# Altium schematic sheet Mainboard.SchDoc
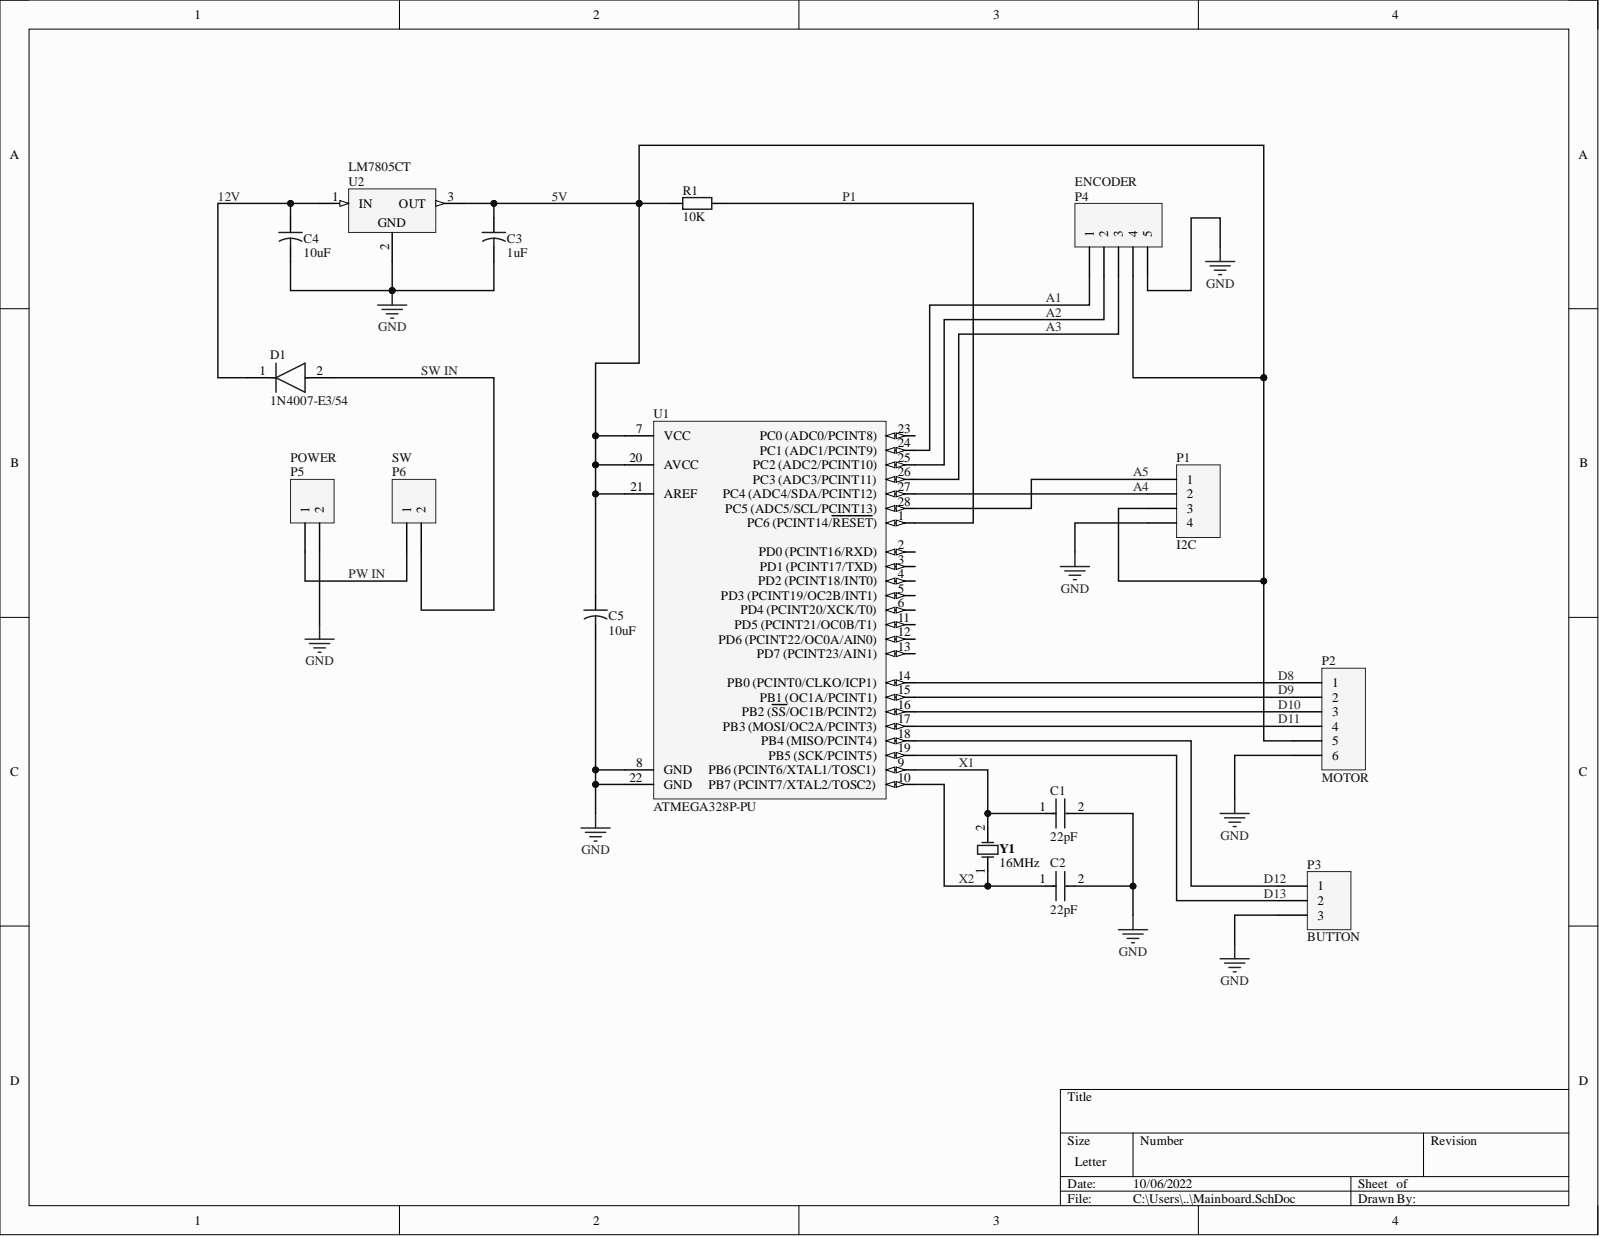
[diagram p0: Mainboard.SchDoc]

## Parts
C1  22pF  [FP-RCE0DB-MFG]
C2  22pF  [FP-RCE0DB-MFG]
C3  1uF  [CAPR5-4X5]
C4  10uF  [CAPR5-4X5]
C5  10uF  [CAPR5-4X5]
D1  1N4007-E3/54  [FP-1N4007-MFG]
P1  I2C  [HDR1X4]
P2  MOTOR  [HDR1X6]
P3  BUTTON  [HDR1X3]
P4  ENCODER  [HDR1X5]
P5  POWER  [HDR1X2]
P6  SW  [HDR1X2]
R1  10K  [AXIAL-0.4]
U1  ATMEGA328P-PU  [ATML-28P3]
U2  LM7805CT  [FAIR-TO-220-3]
Y1  16MHz  [FOX-FOXSLF160-20]

## Nets
GND: C1.2 C2.2 C3.2 C4.2 C5.2 P1.4 P2.6 P3.3 P4.5 P5.2 U1.8(GND) U1.22(GND) U2.2
5V: C3.1 C5.1 P1.3 P2.5 P4.4 R1.1 U1.7(VCC) U1.20(AVCC) U1.21(AREF) U2.3
12V: C4.1 D1.1 U2.1
A1: P4.1 U1.24(PC1_(ADC1/PCINT9))
A2: P4.2 U1.25
A3: P4.3 U1.26(PC3_(ADC3/PCINT11))
A4: P1.2 U1.27
A5: P1.1 U1.28(PC5_(ADC5/SCL/PCINT13))
D8: P2.1 U1.14(PB0_(PCINT0/CLKO/ICP1))
D9: P2.2 U1.15(PB1_(OC1A/PCINT1))
D10: P2.3 U1.16(PB2_(SS/OC1B/PCINT2))
D11: P2.4 U1.17(PB3_(MOSI/OC2A/PCINT3))
D12: P3.1 U1.18(PB4_(MISO/PCINT4))
D13: P3.2 U1.19(PB5_(SCK/PCINT5))
P1: R1.2 U1.1(PC6_(PCINT14/RESET))
PW IN: P5.1 P6.1
SW IN: D1.2 P6.2
X1: C1.1 U1.9 Y1.2
X2: C2.1 U1.10 Y1.1

Not connected: U1 2(PD0_(PCINT16/RXD)) 3(PD1_(PCINT17/TXD)) 4(PD2_(PCINT18/INT0)) 5(PD3_(PCINT19/OC2B/INT1)) 6(PD4_(PCINT20/XCK/T0)) 11(PD5_(PCINT21/OC0B/T1)) 12(PD6_(PCINT22/OC0A/AIN0)) 13(PD7_(PCINT23/AIN1)) 23(PC0_(ADC0/PCINT8))

## Library data of the parts
C1 C2 = CMP-06035-057691-1: Cap Ceramic 22pF 50V C0G ±5% Radial 2.5mm +125°C Ammo Pack; Lead Diameter: 0.5mm; Lead/Base Style: Straight; Capacitance: 22pF; Case/Package: Radial; Component Kind: Standard; Dielectric: C0G; Height: 3.5mm; Height - Seated (Max): 0.138inch; Lead Pitch: 0.098inch; Length: 0.142inch; Library Name: Altium Content Vault; Max Operating Temperature: 125°C; Min Operating Temperature: -55°C; Mount: Through Hole; Package Quantity: 2000; Packaging: Tape and Reel; Ratings: AEC-Q200; Resistor Type: Ceramic; Termination: Radial; Tolerance: 5%; Voltage Rating: 50V; Voltage Rating (DC): 50V; Width: 0.098inch
C3-C5 = Cap2: Capacitor; Component Kind: Standard; Library Name: Miscellaneous Devices.IntLib; PackageDescription: Capacitor; 2 Leads; PackageReference: CAPR5-4X5; Published: 23-Sep-2002; Signal Integrity: Cap; Simulation: CAP; Publisher: Altium Limited
  C3: Value: 1uF
  C4 C5: Value: 10uF
D1 = CMP-02540-000046-1: DIODE GEN PURP 1KV 1A DO204AL; Manufacturer: Vishay; Capacitance: 15pF; Max Junction Temp: +150°C; Max Repetitive Reverse Voltage: 1000V; Max Surge Forward Current: 30A; Peak Reverse Current: 30uA; Value: 15pF; Automotive: No; Category: Diode; Component Kind: Standard; ComponentLink2Description: Datasheet; ComponentLink2URL: http://www.vishay.com/docs/88503/1n4001.pdf; Device Class L1: Discrete Semiconductors; Device Class L2: Diodes; Device Class L3: Rectifier Diodes; Digikey Description: DIODE GEN PURP 1KV 1A DO204AL; Forward Current: 1A; Height: 2.7mm; Ipc Land Pattern Name: DIOPA1088W105L520D270; Lead Free: Yes; Library Name: Altium Content Vault; Manufacturer Part Number: 1N4007-E3/54; Mouser Description: Rectifiers Vr/1000V Io/1A; Octopart: https://octopart.com/1n4007-e3%2F54-vishay-39548207; Package: 1N4007; RoHS: TRUE; Temperature: -50°C to +150°C; Temperature Range High: +150°C; Temperature Range Low: -50°C
P1 = Header 4: Header, 4-Pin; Component Kind: Standard; LatestRevisionDate: 17-Jul-2002; LatestRevisionNote: Re-released for DXP Platform.; Library Name: Miscellaneous Connectors.IntLib; Signal Integrity: Connector; Publisher: Altium Limited
P2 = Header 6: Header, 6-Pin; Component Kind: Standard; LatestRevisionDate: 17-Jul-2002; LatestRevisionNote: Re-released for DXP Platform.; Library Name: Miscellaneous Connectors.IntLib; Signal Integrity: Connector; Publisher: Altium Limited
P3 = Header 3: Header, 3-Pin; Component Kind: Standard; LatestRevisionDate: 17-Jul-2002; LatestRevisionNote: Re-released for DXP Platform.; Library Name: Miscellaneous Connectors.IntLib; Signal Integrity: Connector; Publisher: Altium Limited
P4 = Header 5: Header, 5-Pin; Component Kind: Standard; LatestRevisionDate: 17-Jul-2002; LatestRevisionNote: Re-released for DXP Platform.; Library Name: Miscellaneous Connectors.IntLib; Signal Integrity: Connector; Publisher: Altium Limited
P5 P6 = Header 2: Header, 2-Pin; Component Kind: Standard; LatestRevisionDate: 17-Jul-2002; LatestRevisionNote: Re-released for DXP Platform.; Library Name: Miscellaneous Connectors.IntLib; Signal Integrity: Connector; Publisher: Altium Limited
R1 = Res2: Resistor; Component Kind: Standard; LatestRevisionDate: 17-Jul-2002; LatestRevisionNote: Re-released for DXP Platform.; Library Name: Miscellaneous Devices.IntLib; PackageDescription: Axial Device, Thru-Hole; 2 Leads; 0.4 in Pin Spacing; PackageReference: AXIAL-0.4; Published: 8-Jun-2000; Signal Integrity: Res; Simulation: RESISTOR; Value: 10K; Publisher: Altium Limited
U1 = CMP-020-00004-3: 8-Bit Microcontroller with 32 KBytes In-System Programmable Flash, 23 I/Os, -40 to 85 degC, 28-Pin PDIP (28P3), RoHS, Tube; Manufacturer: Atmel; Density: 32KB; Ethernet Channels: 0; I/Os: 23; Interface: USART; Max Clock Frequency: 20MHz; Max Supply Voltage: 5.5V; Min Supply Voltage: 1.8V; Package Version: Rev. B, 09/2001; RAM Size: 2KB; Case/Package: DIP; Component Kind: Standard; Datasheet URL: http://www.atmel.com/images/Atmel-8271-8-bit-AVR-Microcontroller-ATmega48A-48PA-88A-88PA-168A-168PA-328-328P_datasheet_Complete.pdf; Datasheet Version: Rev. 8271J, 11/2015; Manufacturer URL: http://www.atmel.com/; Max Operating Temperature: 85°C; Min Operating Temperature: -40°C; Mounting Technology: TH; Package Description: 28-Pin Plastic Dual Inline Package, Body 34.671 x 7.3025 mm, Pitch 2.54 mm; Package Quantity: 14; Package Reference: 28P3; Package URL: http://www.atmel.com/images/Atmel-8271-8-bit-AVR-Microcontroller-ATmega48A-48PA-88A-88PA-168A-168PA-328-328P_datasheet_Complete.pdf; Packaging: Rail/Tube; Pins: 28; RoHS Compliant: True; Library Name: University of Moratuwa
U2 = CMP-2000-04938-1: Positive Voltage Regulator, 5 V, 1 A, -40 to 125 degC, 3-Pin TO-220, RoHS, Tube; Manufacturer: FairchildSemiconductor; Accuracy: ±4%; Line Regulation: 100mV; Maximum Input Voltage: 35V; Maximum Output Current: 2.2(Typ)A; Maximum Quiescent Current: 8mA; Number of Outputs: 1; Output Type: Fixed; Output Voltage: 5V; Rad Hard: No; Typical Dropout Voltage @ Current: 2@1AV; Category: LinearRegulator; Component Kind: Standard; Datasheet URL: https://datasheet.ciiva.com/26904/lm7805ct-26904988.pdf; Datasheet Version: Aug-12; Library Name: Altium Content Vault; Load Regulation: 100mV; Manufacturer URL: https://www.fairchildsemi.com/; Mounting: ThroughHole; Operating Temperature: -40to125degC; Package: 3TO-220AB; Package Description: 3-Pin Through Hole Device, Body 10 x 4.5 mm, Pitch 2.54 mm; Package Reference: TO-220; Package URL: https://www.fairchildsemi.com/package-drawings/TO/TO220B03.pdf; Packaging: Rail/Tube; Polarity: Positive; Type: Linear
Y1 = CMP-1749-00003-1: Resistance Weld Thru-Hole Crystal, 16 MHz, -20 to 70 degC, 2-Pin THD, RoHS, Bulk; Case\Package: Axial; Power Rating: 250 mW; Resistance: 221 kohm; Tolerance: 1%; Voltage Rating: 250 V; Component Kind: Standard; ComponentLink1Description: Manufacturer URL; ComponentLink1URL: http://www.foxonline.com/; ComponentLink2Description: Datasheet; ComponentLink2URL: http://www.foxonline.com/pdfs/hc49slf.pdf; DatasheetVersion: Mar-15; Length: 0.248 inch; Library Name: Altium Content Vault; Max Operating Temperature: 155 degC; Min Operating Temperature: -55 degC; Mount: Through Hole; Package Quantity: 200; PackageDescription: 2-Pin Through Hole Device, Body 11.35 x 4.65 mm; PackageReference: FOXSLF160-20; Packaging: Bulk; RoHSCompliant: true
